# Altium schematic sheet atmega.SchDoc
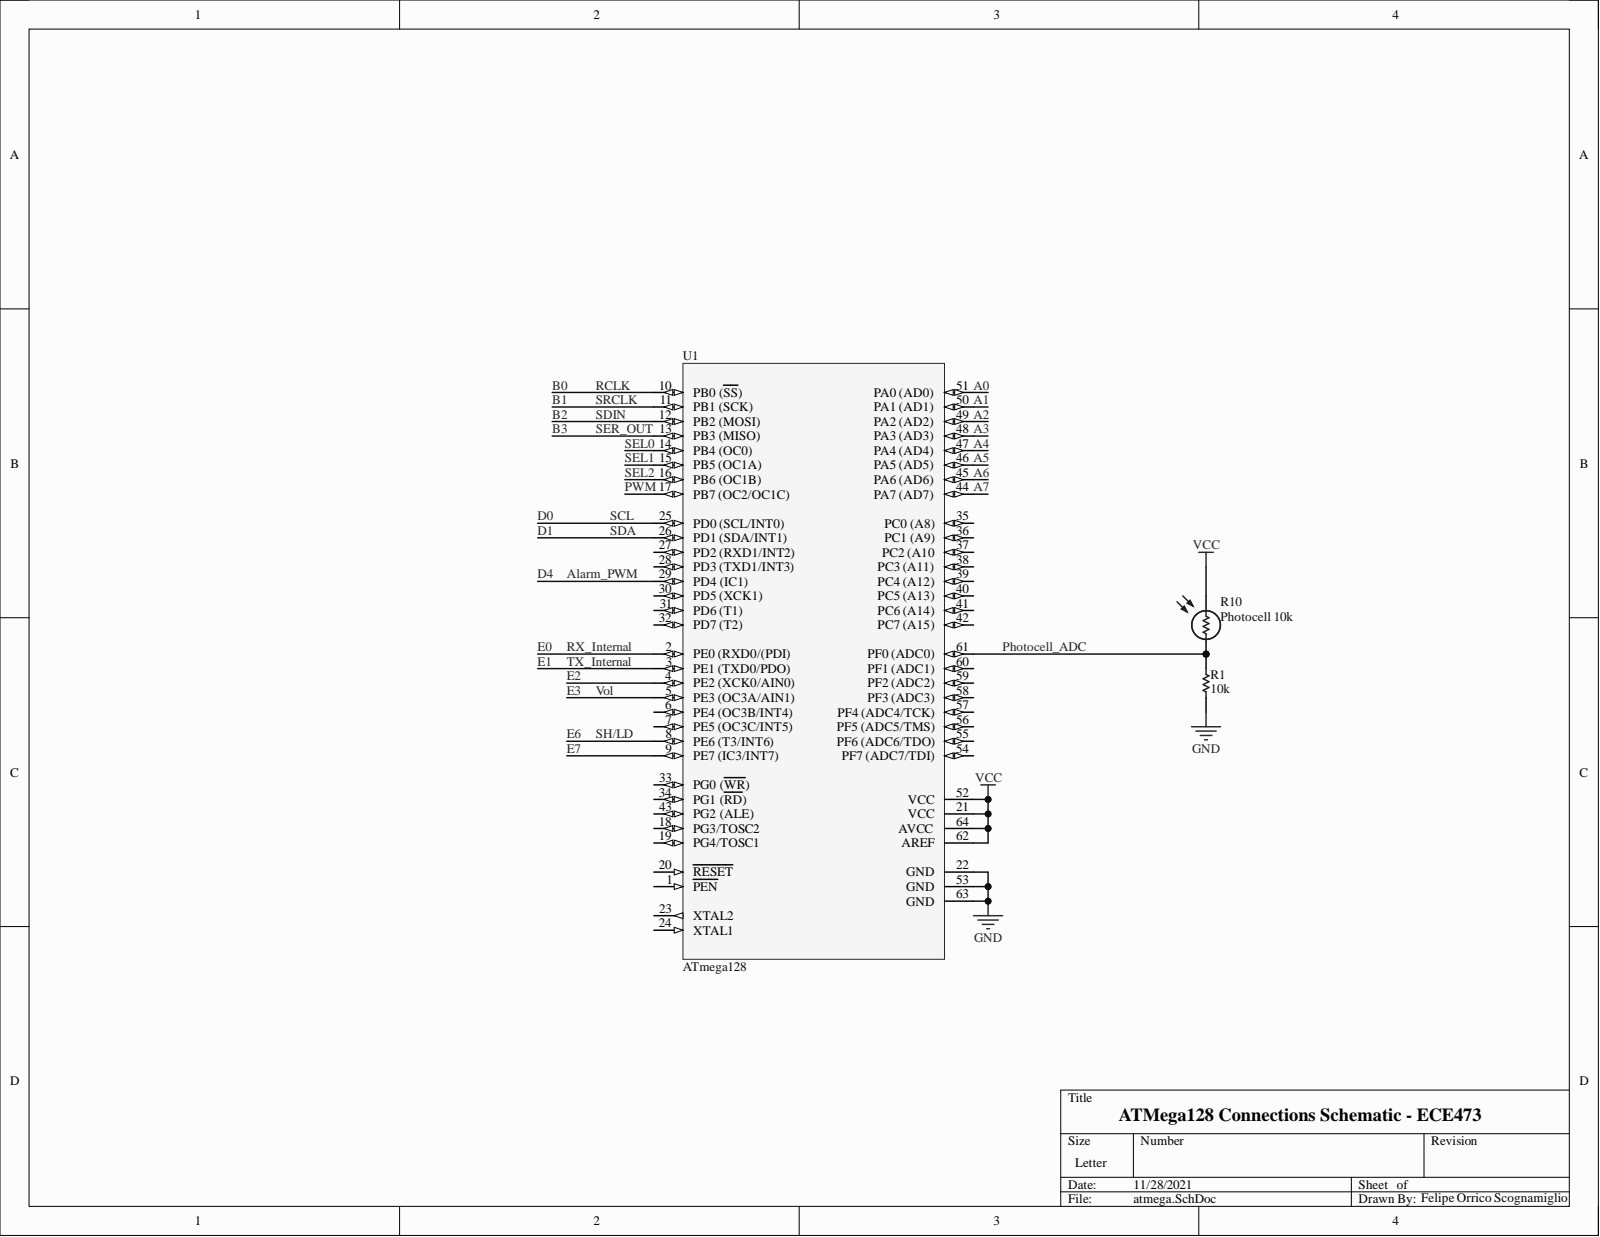
[diagram p0: atmega.SchDoc]

## Parts
R1  10k  [PCB-q466dezs08uszq8774n0-1]
R10  Photocell 10k  [PCB-5xgc5frz4y8haug89ui6-1]
U1  ATmega128  [64A_M]

## Nets
GND: R1.1 U1.22(GND) U1.53(GND) U1.63(GND)
VCC: R10.2 U1.21(VCC) U1.52(VCC) U1.62(AREF) U1.64(AVCC)
A0: U1.51(PA0_(AD0))
A1: U1.50(PA1_(AD1))
A2: U1.49(PA2_(AD2))
A3: U1.48(PA3_(AD3))
A4: U1.47(PA4_(AD4))
A5: U1.46(PA5_(AD5))
A6: U1.45(PA6_(AD6))
A7: U1.44(PA7_(AD7))
Alarm_PWM: U1.29(PD4_(IC1))
B0: U1.10(PB0_(SS))
B1: U1.11(PB1_(SCK))
B2: U1.12(PB2_(MOSI))
B3: U1.13(PB3_(MISO))
D0: U1.25(PD0_(SCL/INT0))
D1: U1.26(PD1_(SDA/INT1))
E0: U1.2(PE0_(RXD0/(PDI))
E1: U1.3(PE1_(TXD0/PDO))
E2: U1.4(PE2_(XCK0/AIN0))
E3: U1.5(PE3_(OC3A/AIN1))
E6: U1.8(PE6_(T3/INT6))
E7: U1.9(PE7_(IC3/INT7))
Photocell_ADC: R1.2 R10.1 U1.61(PF0_(ADC0))
PWM: U1.17(PB7_(OC2/OC1C))
SEL0: U1.14(PB4_(OC0))
SEL1: U1.15(PB5_(OC1A))
SEL2: U1.16(PB6_(OC1B))

Not connected: U1 1(PEN) 6(PE4_(OC3B/INT4)) 7(PE5_(OC3C/INT5)) 18(PG3/TOSC2) 19(PG4/TOSC1) 20(RESET) 23(XTAL2) 24(XTAL1) 27(PD2_(RXD1/INT2)) 28(PD3_(TXD1/INT3)) 30(PD5_(XCK1)) 31(PD6_(T1)) 32(PD7_(T2)) 33(PG0_(WR)) 34(PG1_(RD)) 35(PC0_(A8)) 36(PC1_(A9)) 37(PC2_(A10) 38(PC3_(A11)) 39(PC4_(A12)) 40(PC5_(A13)) 41(PC6_(A14)) 42(PC7_(A15)) 43(PG2_(ALE)) 54(PF7_(ADC7/TDI)) 55(PF6_(ADC6/TDO)) 56(PF5_(ADC5/TMS)) 57(PF4_(ADC4/TCK)) 58(PF3_(ADC3)) 59(PF2_(ADC2)) 60(PF1_(ADC1))

## Library data of the parts
R1 = CMP-e82f23a0358237e8-1: RC Series 0201 0.05 W 1 kOhms 5% ±200 ppm/°C SMT Thick Film Chip Resistor; Manufacturer Part Number: RC0201JR-071KL; Lead-Free Status: Lead Free; Power Rating: 50.0 mW; Resistance: 1.00 kΩ; Voltage Rating (DC): 25.0 V; Case/Package: 0201; Component Kind: Standard; Library Name: My Personal Space; Mounting Style: Surface Mount; Number of Pins: 2; Octopart URL: http://octopart.com/rc0201jr-071kl-yageo-42334411; OctopartID: e82f23a0358237e8; Packaging: Tape & Reel; REACH SVHC Compliance: No SVHC; Resistance Tolerance: ±5%; RoHS: Compliant; Size-Length: 600 µm
R10 = CMP-f9f6313260b42631-7: Lamp; Incandescent; T-3 1/4 Wedge; 14 V; 0.19 A; 1.0 MSCP; 1500 hr; C-2F; Manufacturer Part Number: 161; Supply Voltage (DC): 14.0 V; Component Kind: Standard; Library Name: My Personal Space; Octopart URL: https://octopart.com/161-adafruit+industries-30325796; OctopartID: f9f6313260b42631; Packaging: Bulk; RoHS: Non-Compliant
U1 = CMP-14040106-4: 8-bit AVR Microcontroller, 4.5-5.5V, 128KB Flash, 4KB EEPROM, 4KB SRAM, 64-pin TQFP, Industrial Grade (-40°C to 85°C); Manufacturer Part Number: ATMEGA128-16AU; Access Time: 1.6e-05 s; Clock Speed: 16000000.0 Hz; FLASH Memory Size: 131072 B; Lead-Free Status: Lead Free; Number of Bits: 8; Number of I/O Pins: 53; RAM Memory Size: 4096 B; Supply Voltage (DC): 5.0 V; Case/Package: TQFP; Component Kind: Standard; Core Architecture: AVR; Library Name: My Personal Space; Mounting Style: Surface Mount; Number of Pins: 64; Octopart Url: https://octopart.com/atmega128-16au-atmel-39667098; OctopartID: 4a8f81d82a739548; REACH SVHC Compliance: No SVHC; RoHS: Compliant
